# Altium schematic sheet smart-plug.SchDoc
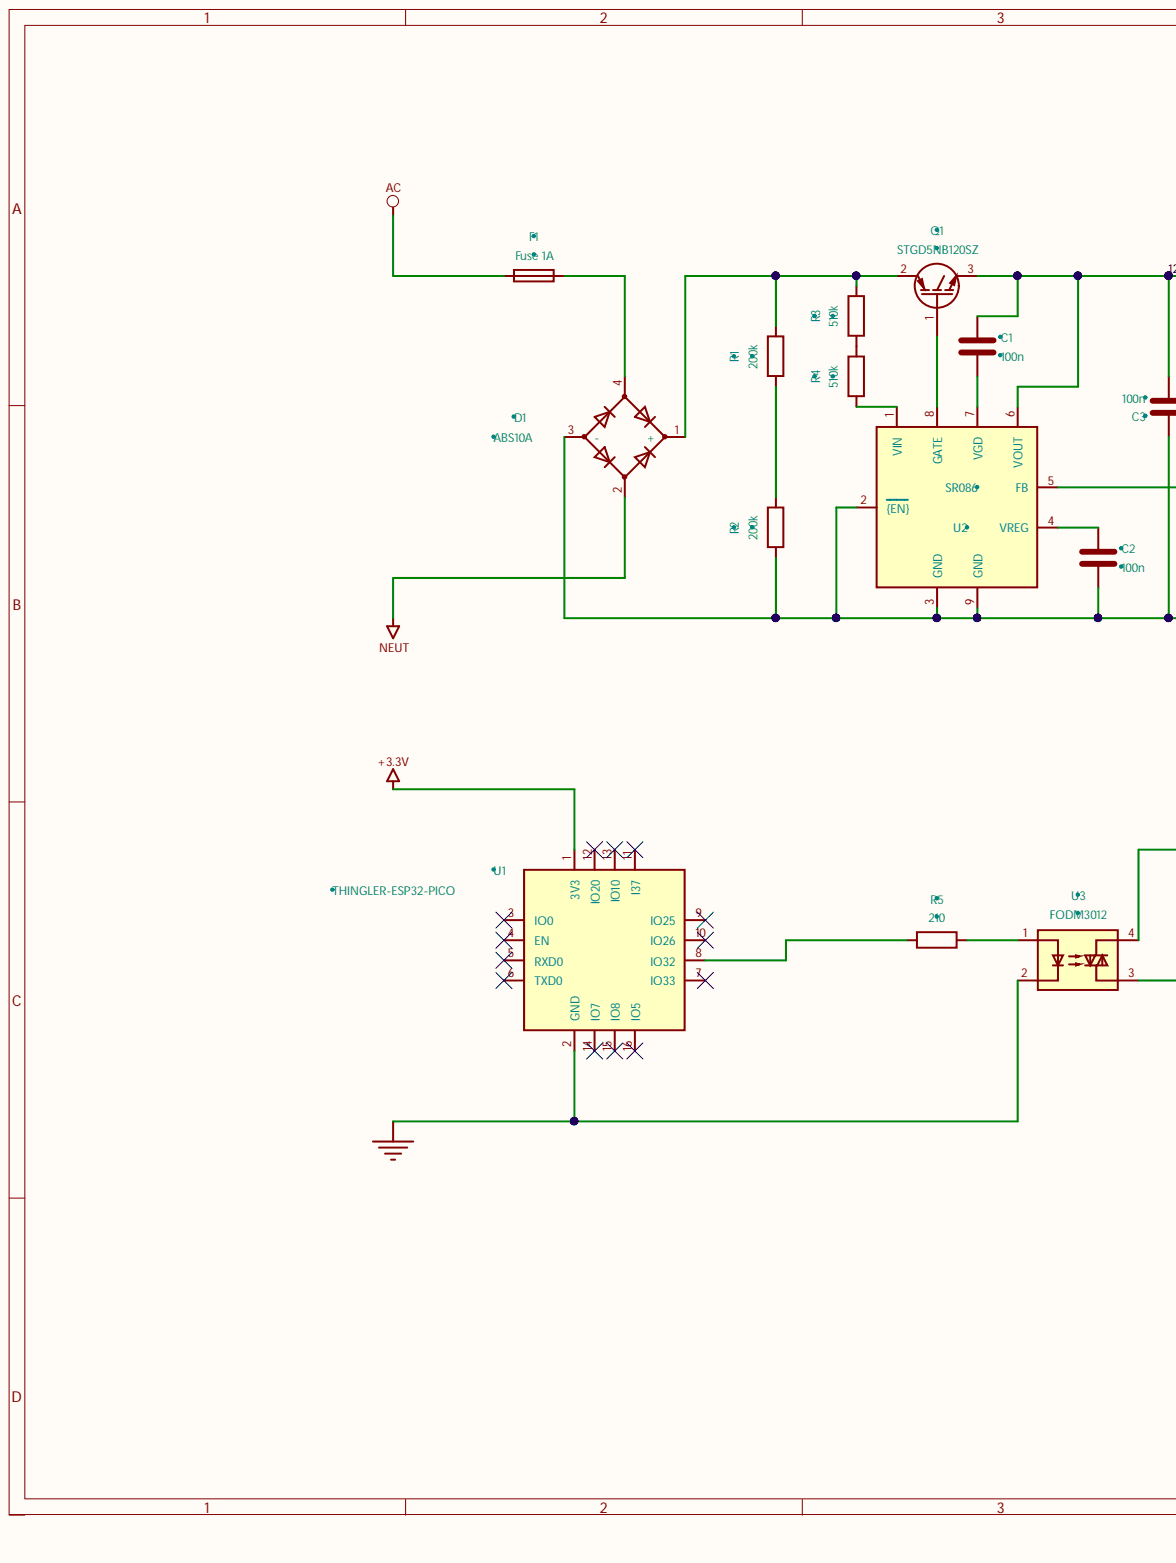
[diagram p0: smart-plug.SchDoc, left]
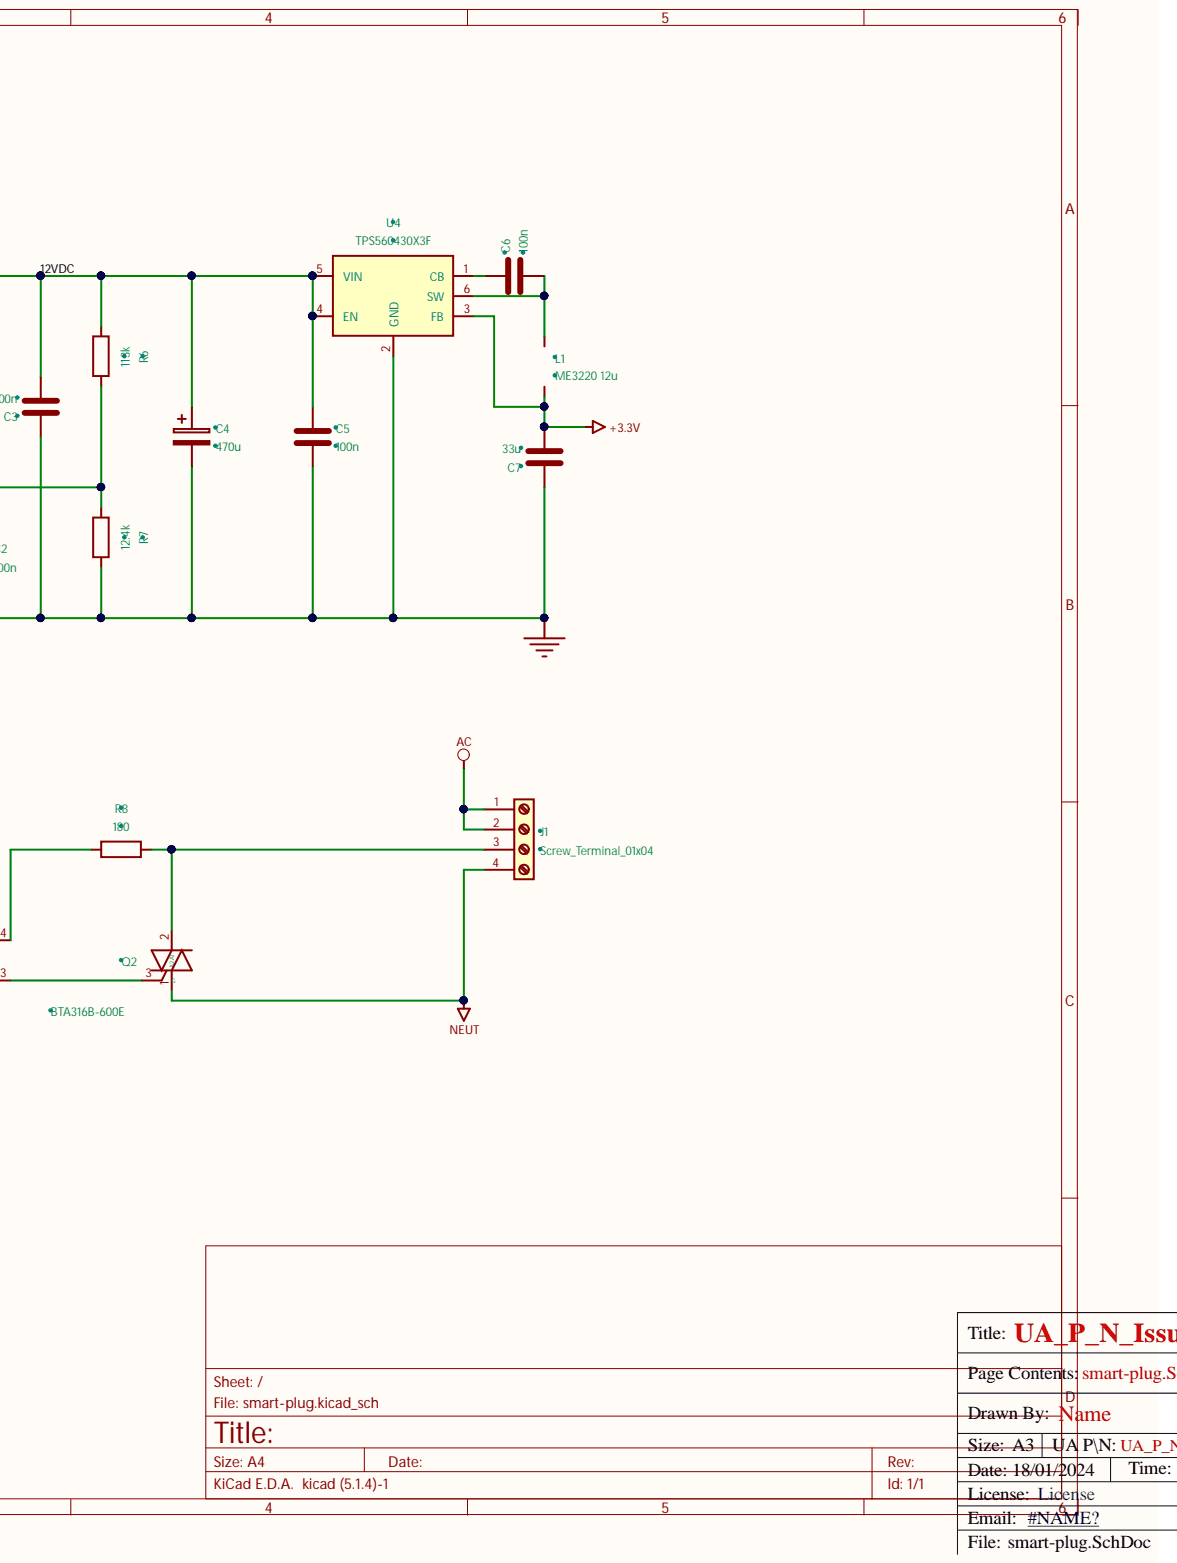
[diagram p1: smart-plug.SchDoc, right]

## Parts
C1  100n  [Capacitor_SMD:C_1206_3216Metric_Pad1.42x1.75mm_HandSolder]
C2  100n  [Capacitor_SMD:C_1206_3216Metric_Pad1.42x1.75mm_HandSolder]
C3  100n  [Capacitor_SMD:C_1206_3216Metric_Pad1.42x1.75mm_HandSolder]
C4  470u  [Capacitor_Tantalum_SMD:CP_EIA-7343-15_Kemet-W]
C5  100n  [Capacitor_SMD:C_1206_3216Metric_Pad1.42x1.75mm_HandSolder]
C6  100n  [Capacitor_SMD:C_1206_3216Metric_Pad1.42x1.75mm_HandSolder]
C7  33u  [Capacitor_SMD:C_1206_3216Metric_Pad1.42x1.75mm_HandSolder]
D1  ABS10A  [smart-plug:ABS10A]
F1  Fuse 1A  [smart-plug:fuse-2410]
J1  Screw_Terminal_01x04  [smart-plug:3d_power_connector]
L1  ME3220 12u  [smart-plug:ME3220]
Q1  STGD5NB120SZ  [Package_TO_SOT_SMD:TO-252-2]
Q2  BTA316B-600E  [smart-plug:to-263-2]
R1  200k  [Resistor_SMD:R_1206_3216Metric_Pad1.42x1.75mm_HandSolder]
R2  200k  [Resistor_SMD:R_1206_3216Metric_Pad1.42x1.75mm_HandSolder]
R3  510k  [Resistor_SMD:R_1206_3216Metric_Pad1.42x1.75mm_HandSolder]
R4  510k  [Resistor_SMD:R_1206_3216Metric_Pad1.42x1.75mm_HandSolder]
R5  210  [Resistor_SMD:R_1206_3216Metric_Pad1.42x1.75mm_HandSolder]
R6  113k  [Resistor_SMD:R_1206_3216Metric_Pad1.42x1.75mm_HandSolder]
R7  12.4k  [Resistor_SMD:R_1206_3216Metric_Pad1.42x1.75mm_HandSolder]
R8  180  [Resistor_SMD:R_1206_3216Metric_Pad1.42x1.75mm_HandSolder]
U1  THINGLER-ESP32-PICO  [smart-plug:Thingler-esp32-vertical]
U2  SR086  [Package_SO:SOIC-8-1EP_3.9x4.9mm_P1.27mm_EP2.29x3mm]
U3  FODM3012  [smart-plug:FODM3012]
U4  TPS560430X3F  [Package_TO_SOT_SMD:SOT-23-6]

## Nets
+3.3V: C7.2 L1.2 U1.1(3V3) U4.3(FB)
AC: F1.2 J1.1 J1.2
EARTH: C2.2 C3.1 C4.2 C5.2 C7.1 D1.3(-) R2.2 R7.1 U1.2(GND) U2.2({EN}) U2.3(GND) U2.9(GND) U3.2 U4.2(GND)
NEUT: D1.2 J1.4 Q2.1
12VDC: C1.1 C3.2 C4.1 C5.1 Q1.3 R6.2 U2.6(VOUT) U4.4(EN) U4.5(VIN)
NetC1_2: C1.2 U2.7(VGD)
NetC2_1: C2.1 U2.4(VREG)
NetC6_1: C6.1 U4.1(CB)
NetC6_2: C6.2 L1.1 U4.6(SW)
NetD1_1: D1.1(+) Q1.2 R1.1 R3.1
NetD1_4: D1.4 F1.1
NetJ1_3: J1.3 Q2.2 R8.1
NetQ1_1: Q1.1 U2.8(GATE)
NetQ2_3: Q2.3 U3.3
NetR1_2: R1.2 R2.1
NetR3_2: R3.2 R4.1
NetR4_2: R4.2 U2.1(VIN)
NetR5_1: R5.1 U3.1
NetR5_2: R5.2 U1.8(IO32)
NetR6_1: R6.1 R7.2 U2.5(FB)
NetR8_2: R8.2 U3.4
NetU1_3: U1.3(IO0)
NetU1_4: U1.4(EN)
NetU1_5: U1.5(RXD0)
NetU1_6: U1.6(TXD0)
NetU1_7: U1.7(IO33)
NetU1_9: U1.9(IO25)
NetU1_10: U1.10(IO26)
NetU1_11: U1.11(I37)
NetU1_12: U1.12(IO20)
NetU1_13: U1.13(IO10)
NetU1_14: U1.14(IO7)
NetU1_15: U1.15(IO8)
NetU1_16: U1.16(IO5)

## Library data of the parts
C1-C3 C5-C7 = C: Component Kind: Standard; Library Name: Device
  C1-C3 C5 C6: Value: 100n
  C7: Value: 33u
C4 = C_Polarized: Component Kind: Standard; Library Name: Device; Value: 470u
D1 = D_Bridge_+A-A: Component Kind: Standard; Library Name: Device; Value: ABS10A
F1 = Fuse: Component Kind: Standard; Library Name: Device; Value: Fuse 1A
L1 = L: Component Kind: Standard; Library Name: Device; Value: ME3220 12u
Q1 = Q_NIGBT_GCE: Component Kind: Standard; Library Name: Device; Value: STGD5NB120SZ
Q2 = BT138-600: Component Kind: Standard; Value: BTA316B-600E; Library Name: Triac_Thyristor
R1-R8 = R: Component Kind: Standard; Library Name: Device
  R1 R2: Value: 200k
  R3 R4: Value: 510k
  R5: Value: 210
  R6: Value: 113k
  R7: Value: 12.4k
  R8: Value: 180
U4 = TPS560430: Component Kind: Standard; Value: TPS560430X3F; Library Name: smart-plug
J1 = Screw_Terminal_01x04: Component Kind: Standard; Library Name: Connector; Value: Screw_Terminal_01x04
U1 = THINGLER-ESP32-PICO: Component Kind: Standard; Library Name: smart-plug; Value: THINGLER-ESP32-PICO
U2 = SR086: Component Kind: Standard; Value: SR086; Library Name: smart-plug
U3 = FODM3012: Component Kind: Standard; Value: FODM3012; Library Name: Relay_SolidState
